# Altium schematic sheet Schematic_12-5-3v3.SchDoc
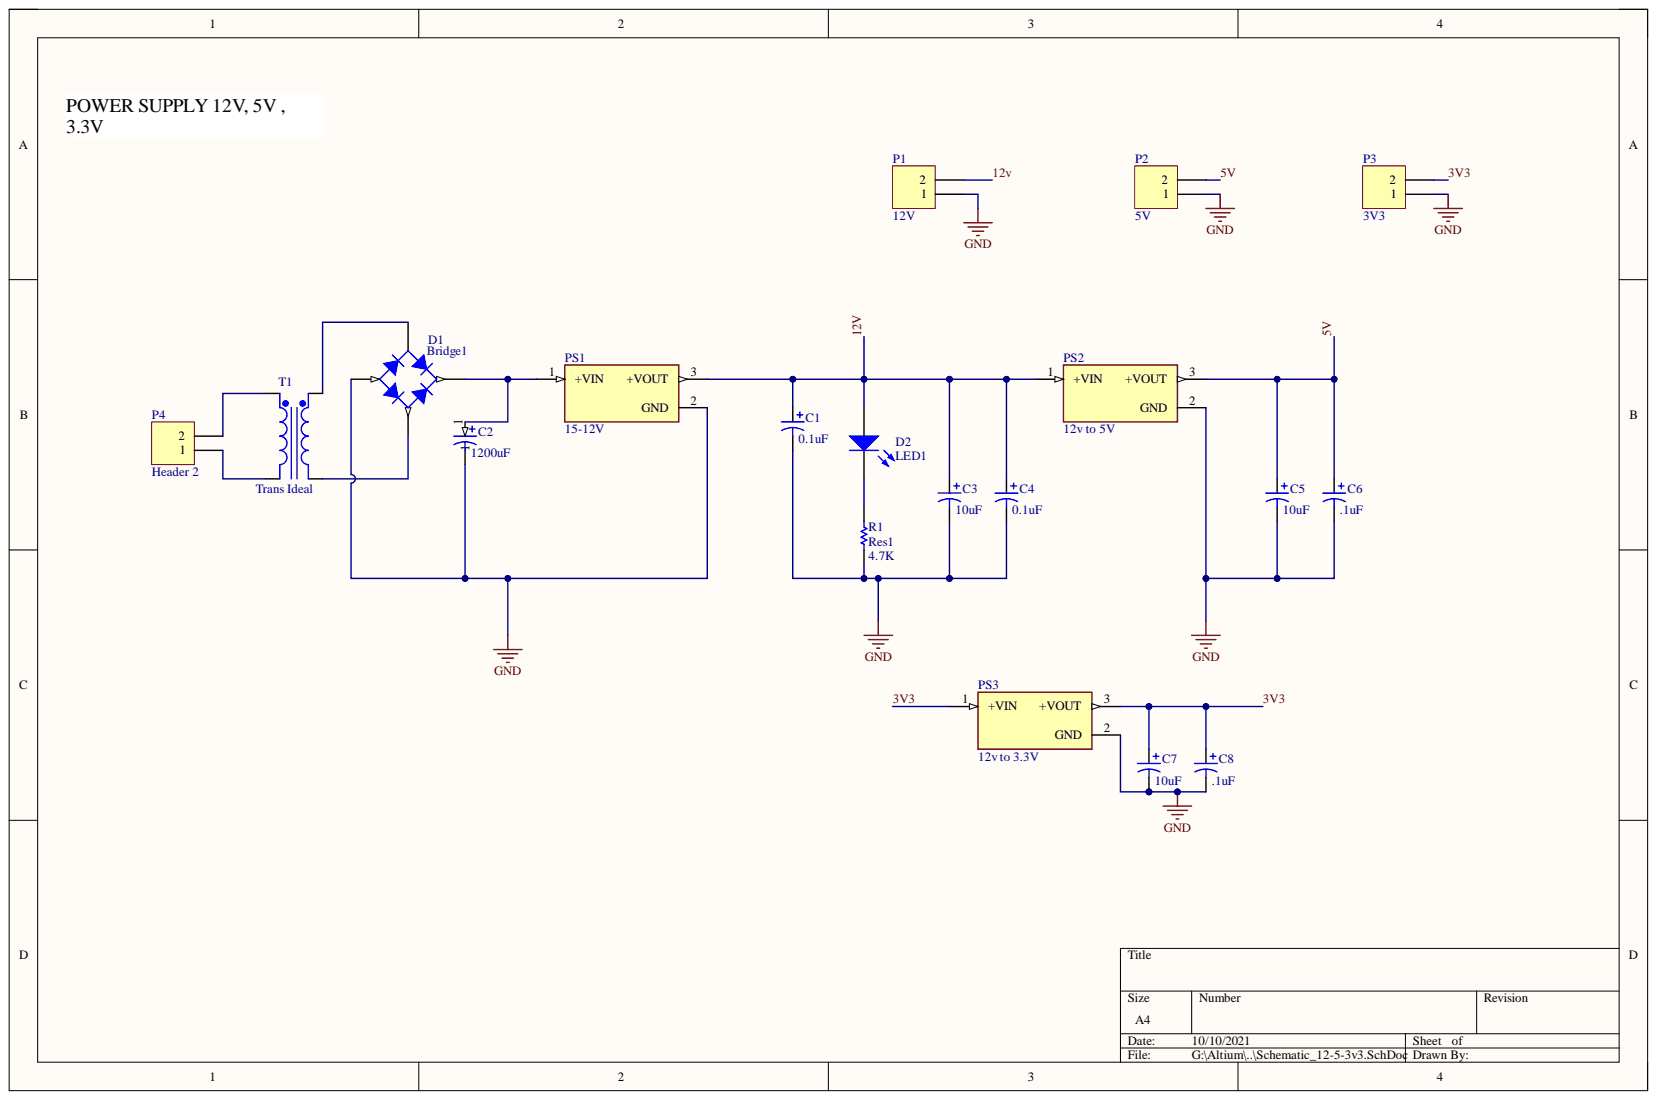
[diagram p0: Schematic_12-5-3v3.SchDoc]

## Parts
C1  value 0.1uF  [1812]  (hidden comment: Cap Pol3)
C2  value 1200uF  [1812]  (hidden comment: Cap Pol3)
C3  value 10uF  [1812]  (hidden comment: Cap Pol3)
C4  value 0.1uF  [1812]  (hidden comment: Cap Pol3)
C5  value 10uF  [1812]  (hidden comment: Cap Pol3)
C6  value .1uF  [1812]  (hidden comment: Cap Pol3)
C7  value 10uF  [1812]  (hidden comment: Cap Pol3)
C8  value .1uF  [1812]  (hidden comment: Cap Pol3)
D1  Bridge1  [D-38]
D2  LED1  [LED-1]
P1  12V  [HDR1X2]
P2  5V  [HDR1X2]
P3  3V3  [HDR1X2]
P4  Header 2  [HDR1X2]
PS1  15-12V  [CONV_R-7812-0.5]
PS2  12v to 5V  [CONV_R-7812-0.5]
PS3  12v to 3.3V  [CONV_R-7812-0.5]
R1  Res1  value 4.7K  [AXIAL-0.3]
T1  Trans Ideal  [TRF_4]

## Nets
GND: C1.2 C2.2 C3.2 C4.2 C5.2 C6.2 C7.2 C8.2 D1.3 P1.1 P2.1 P3.1 PS1.2 PS2.2 PS3.2 R1.1
3V3: C7.1 C8.1 P3.2 PS3.1 PS3.3
5V: C5.1 C6.1 P2.2 PS2.3
12v: C1.1 C3.1 C4.1 D2.1 P1.2 PS1.3 PS2.1
NetC2_1: C2.1 D1.1 PS1.1
NetD1_2: D1.2 T1.4
NetD1_4: D1.4 T1.3
NetD2_2: D2.2 R1.2
NetP4_1: P4.1 T1.2
NetP4_2: P4.2 T1.1

## Library data of the parts
C1-C8 = Cap Pol3: Polarized Capacitor (Surface Mount); Component Kind: Standard; LatestRevisionDate: 29-May-2009; LatestRevisionNote: IPC-7351 Footprint Added.; Library Name: Miscellaneous Devices.IntLib; PackageDescription: Chip Capacitor; PackageReference: C0805; Published: 8-Jun-2000; Simulation: CAP; Publisher: Altium Limited
D1 = Bridge1: Full Wave Diode Bridge; Component Kind: Standard; DatasheetVersion: E2732B; LatestRevisionDate: 29-May-2009; LatestRevisionNote: Sch pins A & K swapped.; Library Name: Miscellaneous Devices.IntLib; PackageDescription: Bridge Rectifier; 4 Terminals; Body 9.4 x 9.4 mm (typ); Pitch 5.08 mm; PackageReference: D-38; Published: 8-Jun-2000; Simulation: BRIDGE; Publisher: Altium Limited
D2 = LED1: Typical RED GaAs LED; Component Kind: Standard; LatestRevisionDate: 08-Jul-2005; LatestRevisionNote: Mech Layer 1 removed.; Library Name: Miscellaneous Devices.IntLib; PackageDescription: LED; 2 Leads; PackageReference: LED-1; Published: 8-Jun-2000; Simulation: LED1; Publisher: Altium Limited
P1-P4 = Header 2: Header, 2-Pin; Component Kind: Standard; LatestRevisionDate: 17-Jul-2002; LatestRevisionNote: Re-released for DXP Platform.; Library Name: Miscellaneous Connectors.IntLib; Publisher: Altium Limited
PS1-PS3 = R-7812-0.5: 0.5A DC/DC-Converter 'INNOLINE' SIP3 reg; MF: Recom Power; Availability: Good; Check_prices: https://www.snapeda.com/parts/R-7812-0.5/Recom%20Power/view-part/531916/?ref=eda; Component Kind: Standard; Library Name: R-7812-0.5.IntLib; MP: R-7812-0.5; Package: SIP-3 Recom Power; Price: None; Purchase-URL: https://pricing.snapeda.com/search/part/R-7812-0.5/?ref=eda; SnapEDA_Link: https://www.snapeda.com/parts/R-7812-0.5/Recom%20Power/view-part/531916/?ref=snap
R1 = Res1: Resistor; Component Kind: Standard; LatestRevisionDate: 17-Jul-2002; LatestRevisionNote: Re-released for DXP Platform.; Library Name: Miscellaneous Devices.IntLib; PackageDescription: Axial Device, Thru-Hole; 2 Leads; 0.3 in Pin Spacing; PackageReference: AXIAL-0.3; Published: 8-Jun-2000; Simulation: RESISTOR; Publisher: Altium Limited
T1 = Trans Ideal: Transformer (Ideal); Component Kind: Standard; LatestRevisionDate: 17-Jul-2002; LatestRevisionNote: Re-released for DXP Platform.; Library Name: Miscellaneous Devices.IntLib; PackageDescription: Transformer; 4 Leads; PackageReference: TRF_4; Published: 8-Jun-2000; Simulation: IDEALTRANS; Publisher: Altium Limited
